# Altium schematic sheet 09_Module_1.SchDoc
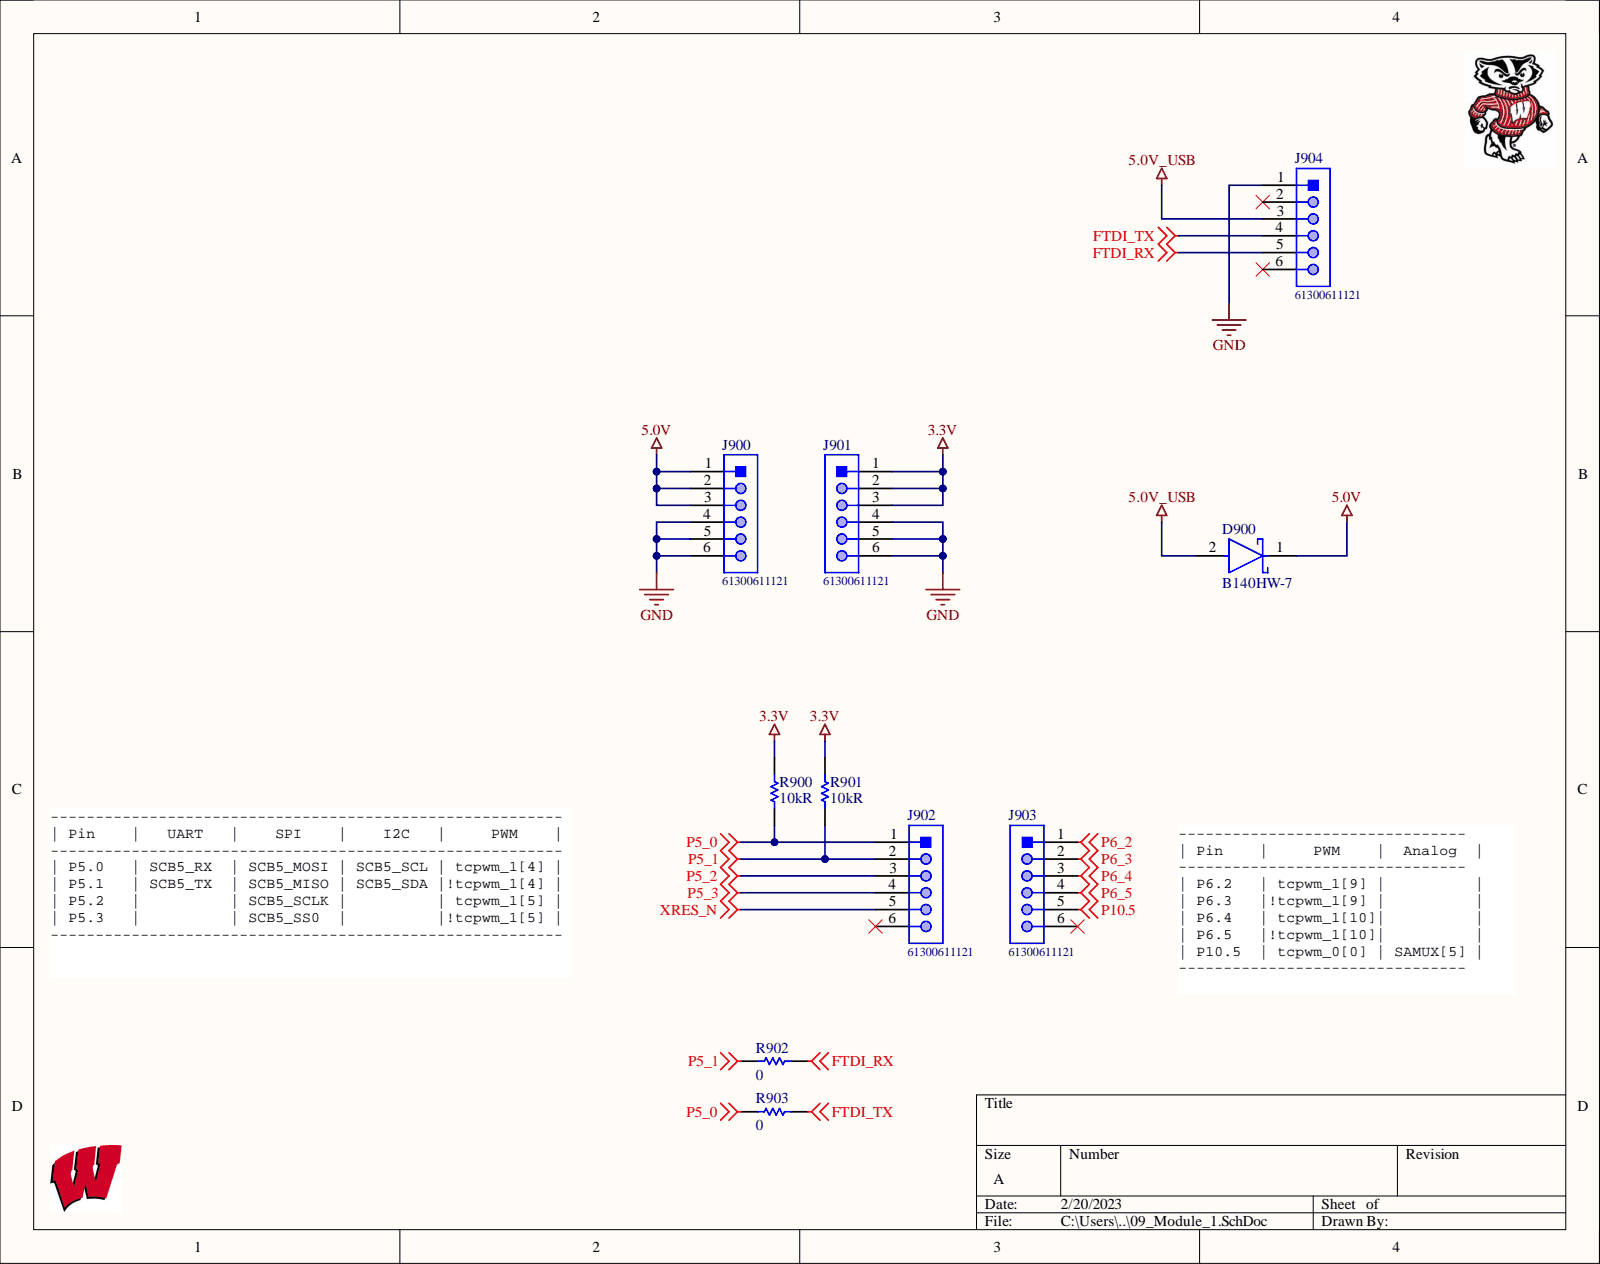
[diagram p0: 09_Module_1.SchDoc]

## Parts
D900  B140HW-7  [FP-SOD123-MFG]
J900  61300611121  [61300611121]
J901  61300611121  [61300611121]
J902  61300611121  [61300611121]
J903  61300611121  [61300611121]
J904  61300611121  [61300611121]
R900  Resistance: 10kR  [RESC2013X60X35NL10T20]  (hidden comment: RC0805FR-0710KL)
R901  Resistance: 10kR  [RESC2013X60X35NL10T20]  (hidden comment: RC0805FR-0710KL)
R902  0  [RES_0805]
R903  0  [RES_0805]

## Nets
3.3V: J901.1 J901.2 J901.3 R900.1 R901.1
5.0V: D900.1 J900.1 J900.2 J900.3
5.0V_USB: D900.2 J904.3
FTDI_RX: J904.5 R902.1
FTDI_TX: J904.4 R903.1
GND: J900.4 J900.5 J900.6 J901.4 J901.5 J901.6 J904.1
P5_0: J902.1 R900.2 R903.2
P5_1: J902.2 R901.2 R902.2
P5_2: J902.3
P5_3: J902.4
P6_2: J903.1
P6_3: J903.2
P6_4: J903.3
P6_5: J903.4
P10.5: J903.5
XRES_N: J902.5

Not connected: J902 6; J903 6; J904 2 6

## Library data of the parts
D900 = CMP-12790-000013-1: DIODE SCHOTTKY 40V 1A SOD123; Manufacturer: Diodes Inc.; Manufacturer 1: Diodes; Capacitance: 120pF; Element Configuration: Single; Forward Voltage: 550mV; Max Forward Surge Current (Ifsm): 16A; Max Junction Temp: +125°C; Max Power Dissipation: 410mW; Max Reverse Current: 40uA; Polarity: Standard; Standoff Height: 0mm; Value: 120pF; Automotive: No; Average Rectified Current: 1A; Category: Diode; Component Kind: Standard; ComponentLink1Description: https://datasheet.ciiva.com/4715/ds30670-86720-4715619.pdf?src-supplier=Mouser; ComponentLink1URL: https://datasheet.ciiva.com/4715/ds30670-86720-4715619.pdf?src-supplier=Mouser; ComponentLink2Description: Datasheet; ComponentLink2URL: https://www.diodes.com/assets/Datasheets/ds30670.pdf; ComponentLink3Description: https://datasheet.ciiva.com/1177/ds30670-1177415.pdf?src-supplier=Element14; ComponentLink3URL: https://datasheet.ciiva.com/1177/ds30670-1177415.pdf?src-supplier=Element14; ComponentLink4Description: https://datasheet.ciiva.com/8301/ds30670-8301643.pdf?src-supplier=Avnet; ComponentLink4URL: https://datasheet.ciiva.com/8301/ds30670-8301643.pdf?src-supplier=Avnet; ComponentLink5Description: https://datasheet.ciiva.com/8303/ds30670-8303271.pdf?src-supplier=Avnet; ComponentLink5URL: https://datasheet.ciiva.com/8303/ds30670-8303271.pdf?src-supplier=Avnet; ComponentLink6Description: https://datasheet.ciiva.com/15220/getdatasheetpartid-245799-15220198.pdf?src-supplier=Verical; ComponentLink6URL: https://datasheet.ciiva.com/15220/getdatasheetpartid-245799-15220198.pdf?src-supplier=Verical; ComponentLink7Description: https://datasheet.ciiva.com/912/ds30670-912808.pdf?src-supplier=Digi-Key; ComponentLink7URL: https://datasheet.ciiva.com/912/ds30670-912808.pdf?src-supplier=Digi-Key; ComponentLink8Description: https://datasheet.ciiva.com/912/ds30670-912809.pdf?src-supplier=Digi-Key; ComponentLink8URL: https://datasheet.ciiva.com/912/ds30670-912809.pdf?src-supplier=Digi-Key; ComponentLink9Description: https://datasheet.ciiva.com/912/ds30670-912807.pdf?src-supplier=Digi-Key; ComponentLink9URL: https://datasheet.ciiva.com/912/ds30670-912807.pdf?src-supplier=Digi-Key; Contact Plating: Tin,Matte; Current Rating: 1A; Device Class L1: Discrete Semiconductors; Device Class L2: Diodes; Device Class L3: Schottky Diodes; Digikey Description: DIODE SCHOTTKY 40V 1A SOD123; Footprint Url: https://www.diodes.com/assets/Package-Files/SOD123.pdf; Forward Current: 1A; Height: 1.45mm; Ipc Land Pattern Name: SOD365155X110-2; Lead Free: Yes; Length: 2.85mm; Library Name: Altium Content Vault; Manufacturer Part Number: B140HW-7; Manufacturer Part Number 1: B140HW-7; Max Operating Temperature: 125°C; Max Repetitive Reverse Voltage: 40V; Max Repetitive Reverse Voltage (Vrrm): 40V; Max Reverse Voltage (DC): 40V; Max Surge Forward Current: 16A; Min Operating Temperature: -65°C; Mount: Surface Mount; Mouser Description: Schottky Diodes & Rectifiers SCHOTTKY RECTIFIER SOD-123 1.0A 40V; Number of Pins: 2; Octopart: https://octopart.com/b140hw-7-diodes+inc.-325751; Output Current: 1A; Package: SOD123; Package Quantity: 3000; Packaging: Tape and Reel; Peak Non-Repetitive Surge Current: 16A; Peak Reverse Current: 40uA; Pricing 1: 1=0.47000, 10=0.34600, 100=0.19600, 500=0.12978, 1000=0.09950 (USD); Radiation Hardening: No; Reach SVHC Compliant: Yes; Reverse Voltage: 40V; RoHS: TRUE; RoHS Compliant: Yes; Series: -; Stock 1: 101818; Supplier 1: Digi-Key; Supplier Part Number 1: B140HWDICT-ND; Temperature: -65°C to +125°C; Temperature Range High: +125°C; Temperature Range Low: -65°C; Voltage Rating (DC): 40V; Weight: 0.000353oz; Width: 1.7mm
J900-J904 = CMP-1502-01070-1: THT Vertical Pin Header WR-PHD, Pitch 2.54 mm, Single Row, 6 pins; Manufacturer: Wurth Elektronik; Manufacturer 1: Wurth Electronics; Connector Type: Male Pin; Contact Resistance: 20mOhm; Current Rating: 3A; Gender: Male; Number of Contacts: 6; Pitch: 2.54mm; Voltage Rating: 250V; Withstanding Voltage: 500VAC; Working Voltage: 250VAC; Category: Headers and Wire Housings; Color: Black; Component Kind: Standard; ComponentLink1Description: http://www.mouser.com/ds/2/445/6130xx11121-538006.pdf?src-supplier=Mouser; ComponentLink1URL: http://www.mouser.com/ds/2/445/6130xx11121-538006.pdf?src-supplier=Mouser; ComponentLink2Description: http://www.farnell.com/cad/1737268.pdf?src-supplier=Element14; ComponentLink2URL: http://www.farnell.com/cad/1737268.pdf?src-supplier=Element14; ComponentLink3Description: https://katalog.we-online.de/em/datasheet/6130xx11121.pdf?src-supplier=Digi-Key; ComponentLink3URL: https://katalog.we-online.de/em/datasheet/6130xx11121.pdf?src-supplier=Digi-Key; Contact Gender: Male; Contact Material: Copper Alloy,Copper; Contact Mating Length: 0.236inch; Contact Plating: Gold; Contact Type: Male Pin; Datasheet URL: https://katalog.we-online.de/em/datasheet/6130xx11121.pdf; Housing Material: PBT; Lead Free: Lead Free; Library Name: Altium Content Vault; Manufacturer Part Number 1: 61300611121; Manufacturer URL: http://www.we-online.de; Max Operating Temperature: 125°C; Min Operating Temperature: -40°C; Mount: Through Hole; Mounting Technology: THT; Number of Positions: 6; Number of Rows: 1; Operating Temperature: -40to105degC; Orientation: Vertical; Package Reference: 61300611121; Packaging: Bag; Part Number: 61300611121; Pin Number: 6; Pricing 1: 1=0.35000, 10=0.29800, 25=0.24720, 50=0.20700, 100=0.18700, 1000=0.17100 (USD); Rated Current: 3A; RoHS: Yes; RoHS Compliant: Yes; Row Number: Single; Series: WR-PHD; Stock 1: 51753; Supplier 1: Digi-Key; Supplier Part Number 1: 732-5319-ND; Termination: Through Hole; Publisher: WurthElektronik
R900 R901 = CMP-1659-00013-4: Chip Resistor, 10 KOhm, +/- 1%, 0.125 W, -55 to 155 degC, 0805 (2012 Metric), RoHS, Tape and Reel; Manufacturer: Yageo; Max Power Dissipation: 125mW; Temperature Coefficient: 100ppm/°C; Voltage Rating: 150 V; Case Code (Imperial): 0805; Case Code (Metric): 2012; Case/Package: 0805; Category: Chip SMD Resistors; Component Kind: Standard; ComponentLink1Description: Manufacturer URL; ComponentLink1URL: http://www.yageo.com/; ComponentLink2Description: Datasheet; ComponentLink2URL: http://www.yageo.com/documents/recent/PYu-RC_Group_51_RoHS_L_04.pdf; Construction: Rectangular; DatasheetVersion: Rev. 4, 02/2016; Depth: 1.25mm; Features: Moisture Resistant; Height: 0.5 mm; Lead Free: Lead Free; Length: 2 mm; Library Name: Altium Content Vault; Manufacturer 1: Yageo; Manufacturer Part Number 1: RC0805FR-0710KL; Max Operating Temperature: 155 degC; Military Standard: Not; Min Operating Temperature: -55 degC; Mount: Surface Mount; Mounting Technology: Surface Mount; Number of Terminations: 2; Package Quantity: 5000; PackageDescription: 2-Pin Surface Mounted Device, Body 2 x 1.25 mm; PackageReference: 0805; Packaging: Tape and Reel; Pins: 2; Power Rating: 125mW; Pricing 1: 1=0.10000, 10=0.03700, 100=0.01520, 1000=0.00682, 2500=0.00592 (USD); REACH SVHC: No SVHC; Resistance Tolerance: 1%; Resistor Type: Thick Film; RoHS: Yes; RoHS Compliant: Yes; RoHSCompliant: true; Series: RC; Size Code: 0805; Stock 1: 12202331; Supplier 1: Digi-Key; Supplier Part Number 1: 311-10.0KCRCT-ND; Technology: THICK FILM; Termination: SMD/SMT; Tolerance: 1%; Width: 0.049inch
R902 R903 = RES_0805_000.00: RES 0, , 1%, 0.125W, 1/8W 0805; Manufacturer: Panasonic Electronic Components; Manufacturer Part Number: ERJ-6GEY0R00V; Tolerance: Jumper; Category: Resistors; Component Kind: Standard; Composition: Thick Film; Features: Automotive AEC-Q200; Height: 0.028" (0.70mm); Library Name: Resistors.SchLib; Number of Terminations: 2; Operating Temperature: -55°C ~ 155°C; Package / Case: 0805 (2012 Metric); Packaging: Cut Tape (CT); Power (Watts): 0.125W, 1/8W; Resistance: 0; RoHS: RoHS Compliant; Size / Dimension: 0.079" L x 0.049" W (2.00mm x 1.25mm); Supplier: Digi-Key; Supplier 1: Digi-Key; Supplier Device Package: 0805; Supplier Part Number: P0.0ACT-ND; Supplier Part Number 1: P0.0ACT-ND; Temperature Coefficient: -; Value: 0
